# Altium schematic sheet GIG_ENET.SchDoc(GIG_ENET)
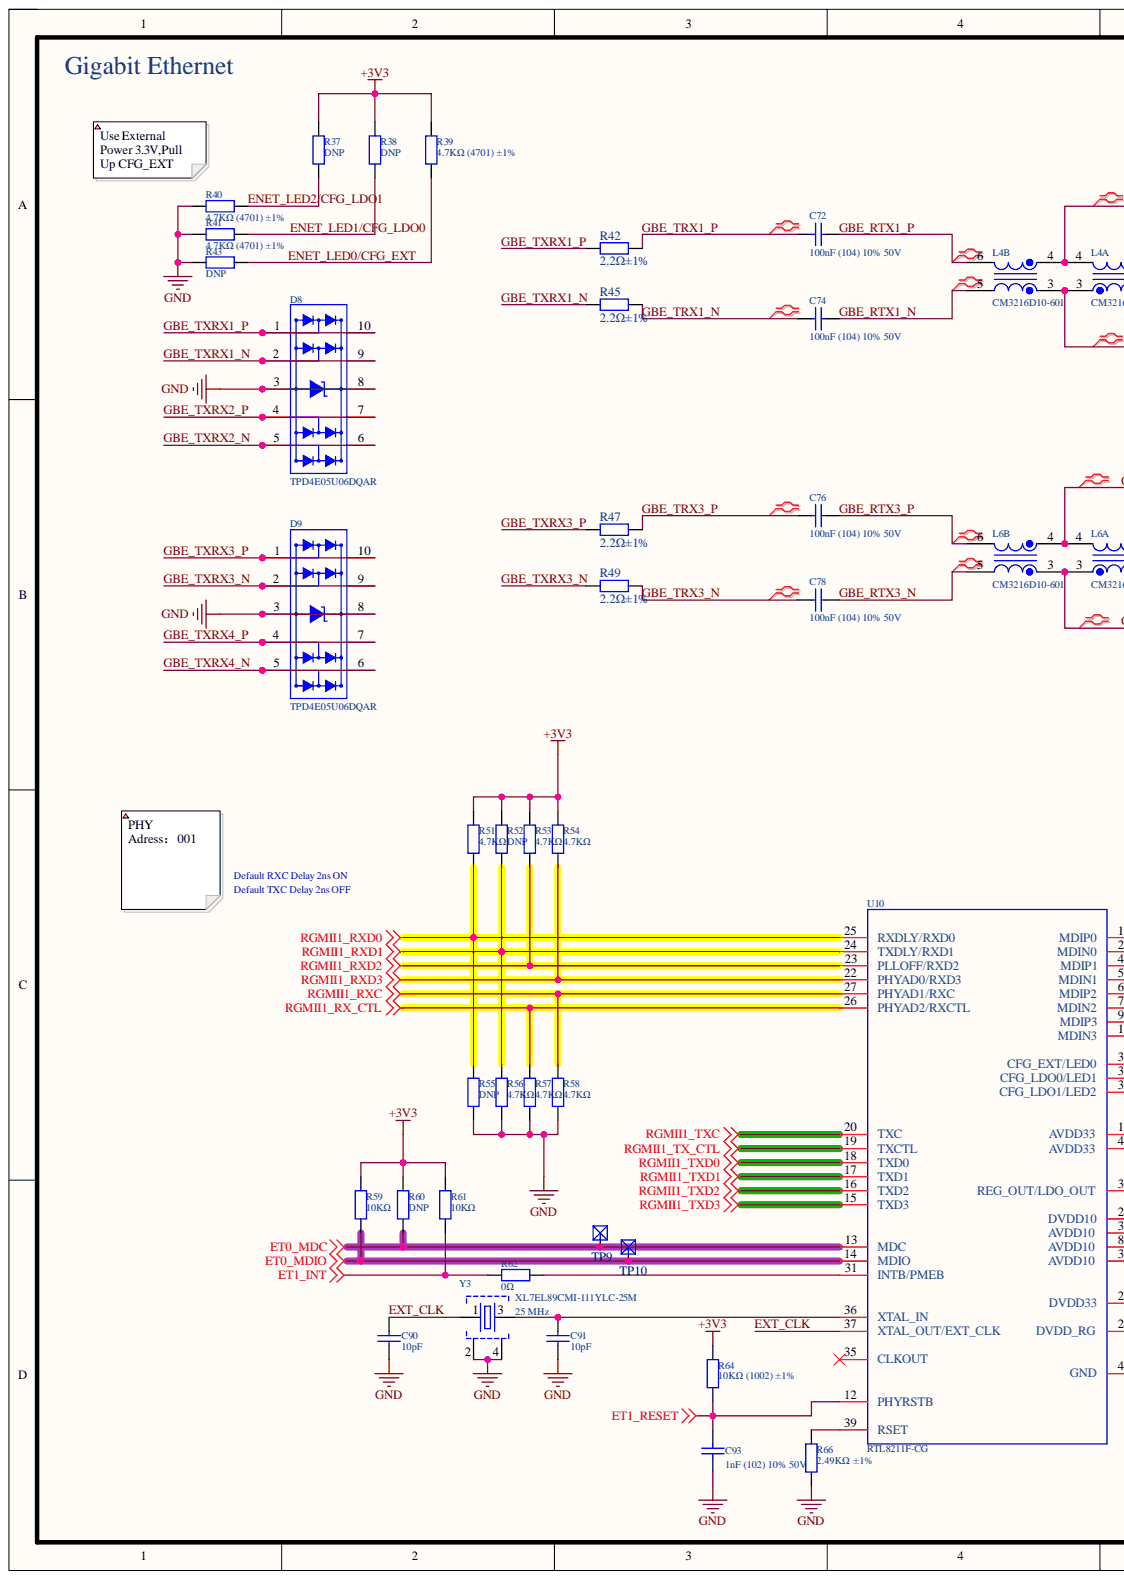
[diagram p0: GIG_ENET.SchDoc, left]
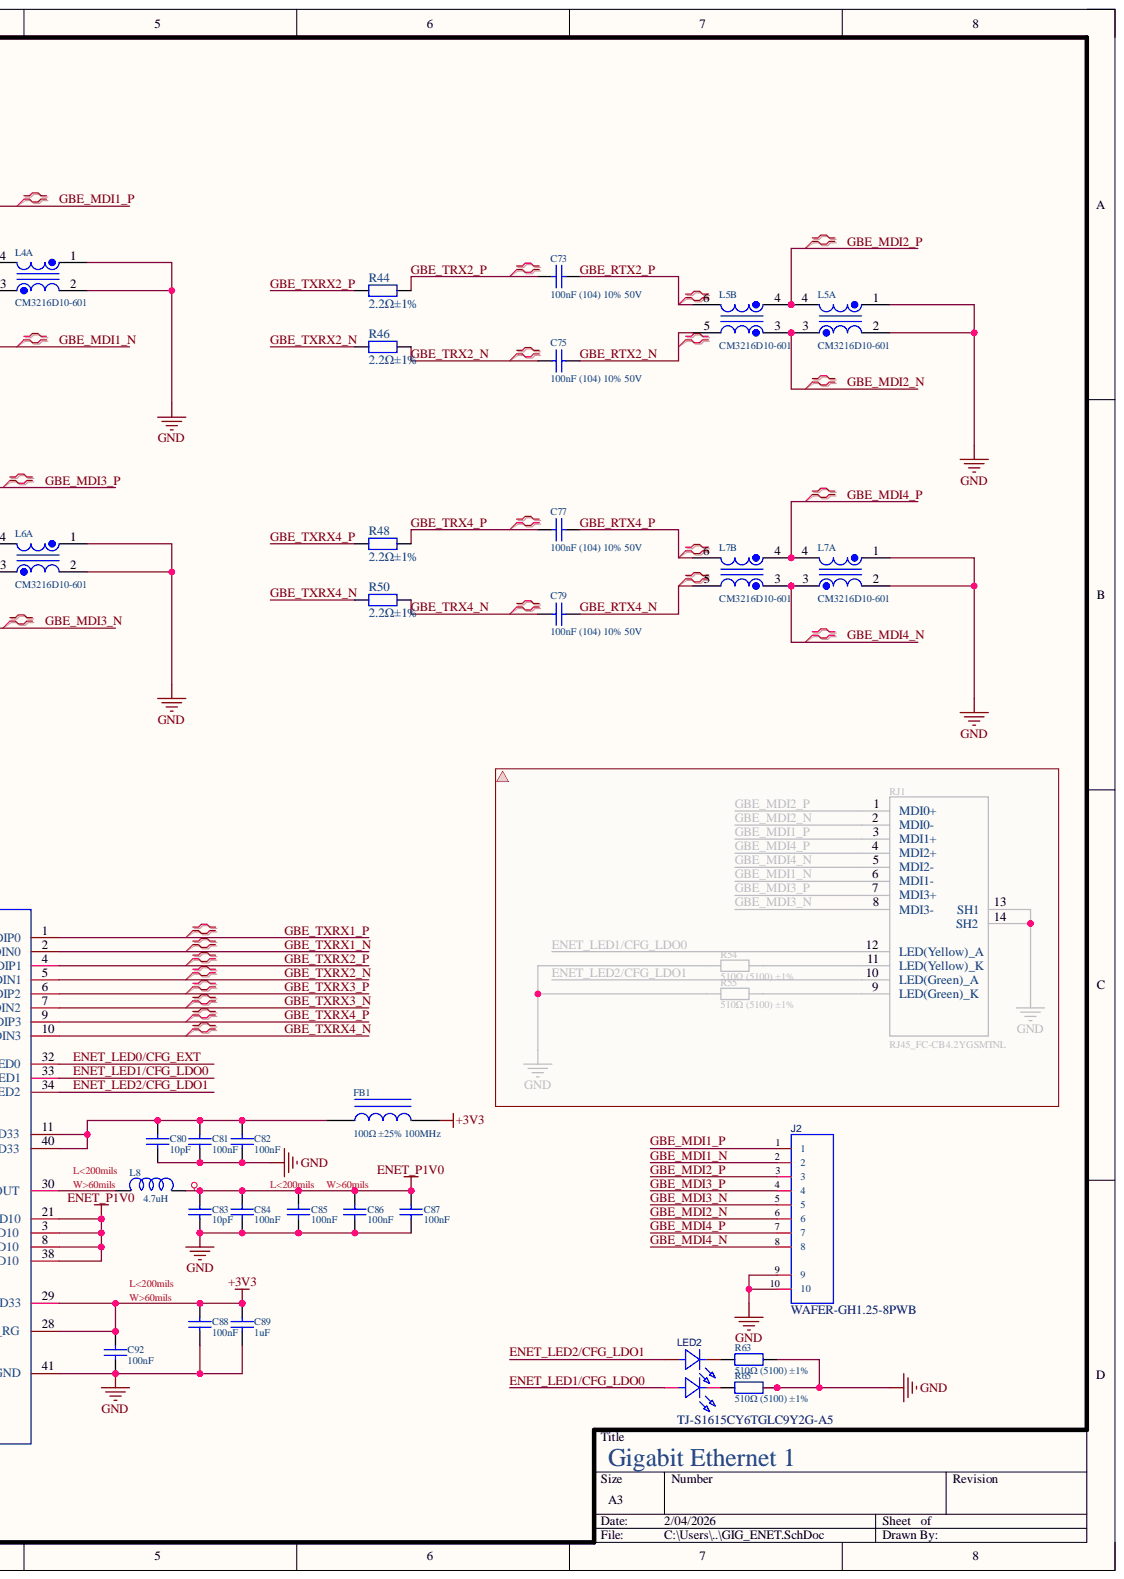
[diagram p1: GIG_ENET.SchDoc, right]

## Parts
C72  100nF (104) 10% 50V  [C0402]
C73  100nF (104) 10% 50V  [C0402]
C74  100nF (104) 10% 50V  [C0402]
C75  100nF (104) 10% 50V  [C0402]
C76  100nF (104) 10% 50V  [C0402]
C77  100nF (104) 10% 50V  [C0402]
C78  100nF (104) 10% 50V  [C0402]
C79  100nF (104) 10% 50V  [C0402]
C80  10pF  [C0402]
C81  100nF  [C0201]
C82  100nF  [C0201]
C83  10pF  [C0402]
C84  100nF  [C0201]
C85  100nF  [C0201]
C86  100nF  [C0201]
C87  100nF  [C0201]
C88  100nF  [C0201]
C89  Capacitance: 1uF  [C0402]  (hidden comment: 1uF 16V)
C90  10pF  [C0402]
C91  10pF  [C0402]
C92  100nF  [C0201]
C93  1nF (102) 10% 50V  [C0402]
D8  TPD4E05U06DQAR  [USON-10_L2.5-W1.0-P0.50-BL]
D9  TPD4E05U06DQAR  [USON-10_L2.5-W1.0-P0.50-BL]
FB1  100Ω ±25% 100MHz  [0805_L]
J2  WAFER-GH1.25-8PWB  [CONN-SMD_8P-P1.25_XUNPU-WAFER-GH1.25-8PWB]
L4  CM3216D10-601  [FILTER-SMD_6P-L3.4-W1.6_SFI1206-601R201]  (parts A,B)
L5  CM3216D10-601  [FILTER-SMD_6P-L3.4-W1.6_SFI1206-601R201]  (parts A,B)
L6  CM3216D10-601  [FILTER-SMD_6P-L3.4-W1.6_SFI1206-601R201]  (parts A,B)
L7  CM3216D10-601  [FILTER-SMD_6P-L3.4-W1.6_SFI1206-601R201]  (parts A,B)
L8  Inductance: 4.7uH  [FB_0805]  (hidden comment: IND-100023-01)
LED2  TJ-S1615CY6TGLC9Y2G-A5  [LED-SMD_4P-L1.6-W1.5_TJ-S1615CY6TGLC9Y2G-A5]
R37  DNP  [R0402]
R38  DNP  [R0402]
R39  4.7KΩ (4701) ±1%  [R0402]
R40  4.7KΩ (4701) ±1%  [R0402]
R41  4.7KΩ (4701) ±1%  [R0402]
R42  2.2Ω±1%  [R0201]
R43  DNP  [R0402]
R44  2.2Ω±1%  [R0201]
R45  2.2Ω±1%  [R0201]
R46  2.2Ω±1%  [R0201]
R47  2.2Ω±1%  [R0201]
R48  2.2Ω±1%  [R0201]
R49  2.2Ω±1%  [R0201]
R50  2.2Ω±1%  [R0201]
R51  4.7KΩ  [R0402]
R52  DNP  [R0402]
R53  4.7KΩ  [R0402]
R54  4.7KΩ  [R0402]
R55  DNP  [R0402]
R56  4.7KΩ  [R0402]
R57  4.7KΩ  [R0402]
R58  4.7KΩ  [R0402]
R59  10KΩ  [R0402]
R60  DNP  [R0402]
R61  10KΩ  [R0402]
R62  0Ω  [R0402]
R63  510Ω (5100) ±1%  [R0402]
R64  10KΩ (1002) ±1%  [R0402]
R65  510Ω (5100) ±1%  [R0402]
R66  2.49KΩ  ±1%  [R0402]
TP9  TEST POINT  [TP_M_0.5]  (value not drawn)
TP10  TEST POINT  [TP_M_0.5]  (value not drawn)
U10  RTL8211F-CG  [WQFN-40_L5.0-W5.0-P0.4-BL-EP]
Y3  XL7EL89CMI-111YLC-25M  [CYR-2016]

## Nets
+3V3: C88.1 C89.1 C92.1 FB1.2 R37.2 R38.2 R39.2 R51.2 R52.2 R53.2 R54.2 R59.2 R60.2 R61.2 R64.2 U10.28(DVDD_RG) U10.29(DVDD33)
ENET_P1V0: C83.2 C84.2 C85.2 C86.2 C87.2 L8.2 U10.3(AVDD10) U10.8(AVDD10) U10.21(DVDD10) U10.38(AVDD10)
ET0_MDC: R60.1 TP9.1 U10.13(MDC)
ET0_MDIO: R59.1 TP10.1 U10.14(MDIO)
ET1_INT: R61.1 R62.1
ET1_RESET: C93.2 R64.1 U10.12(PHYRSTB)
GND: C80.2 C81.1 C82.2 C83.1 C84.1 C85.1 C86.1 C87.1 C88.2 C89.2 C90.1 C91.1 C92.2 C93.1 D8.3 D8.8 D9.3 D9.8 J2.9 J2.10 L4.1 L4.2 L5.1 L5.2 L6.1 L6.2 L7.1 L7.2 R40.1 R41.1 R43.1 R55.1 R56.1 R57.1 R58.1 R63.1 R65.1 R66.1 U10.41(GND) Y3.2 Y3.4
RGMII1_RX_CTL: R57.2 U10.26(PHYAD2/RXCTL)
RGMII1_RXC: R58.2 U10.27(PHYAD1/RXC)
RGMII1_RXD0: R51.1 R55.2 U10.25(RXDLY/RXD0)
RGMII1_RXD1: R52.1 R56.2 U10.24(TXDLY/RXD1)
RGMII1_RXD2: R53.1 U10.23(PLLOFF/RXD2)
RGMII1_RXD3: R54.1 U10.22(PHYAD0/RXD3)
RGMII1_TX_CTL: U10.19(TXCTL)
RGMII1_TXC: U10.20(TXC)
RGMII1_TXD0: U10.18(TXD0)
RGMII1_TXD1: U10.17(TXD1)
RGMII1_TXD2: U10.16(TXD2)
RGMII1_TXD3: U10.15(TXD3)
ENET_LED0/CFG_EXT: R39.1 R43.2 U10.32(CFG_EXT/LED0)
ENET_LED1/CFG_LDO0: LED2.1 R38.1 R41.2 U10.33(CFG_LDO0/LED1)
ENET_LED2/CFG_LDO1: LED2.3 R37.1 R40.2 U10.34(CFG_LDO1/LED2)
EXT_CLK: C90.2 U10.37(XTAL_OUT/EXT_CLK) Y3.1
GBE_MDI1_N: J2.2 L4.3
GBE_MDI1_P: J2.1 L4.4
GBE_MDI2_N: J2.6 L5.3
GBE_MDI2_P: J2.3 L5.4
GBE_MDI3_N: J2.5 L6.3
GBE_MDI3_P: J2.4 L6.4
GBE_MDI4_N: J2.8 L7.3
GBE_MDI4_P: J2.7 L7.4
GBE_RTX1_N: C74.2 L4.5
GBE_RTX1_P: C72.2 L4.6
GBE_RTX2_N: C75.2 L5.5
GBE_RTX2_P: C73.2 L5.6
GBE_RTX3_N: C78.2 L6.5
GBE_RTX3_P: C76.2 L6.6
GBE_RTX4_N: C79.2 L7.5
GBE_RTX4_P: C77.2 L7.6
GBE_TRX1_N: C74.1 R45.2
GBE_TRX1_P: C72.1 R42.2
GBE_TRX2_N: C75.1 R46.2
GBE_TRX2_P: C73.1 R44.2
GBE_TRX3_N: C78.1 R49.2
GBE_TRX3_P: C76.1 R47.2
GBE_TRX4_N: C79.1 R50.2
GBE_TRX4_P: C77.1 R48.2
GBE_TXRX1_N: D8.2 D8.9 R45.1 U10.2(MDIN0)
GBE_TXRX1_P: D8.1 D8.10 R42.1 U10.1(MDIP0)
GBE_TXRX2_N: D8.5 D8.6 R46.1 U10.5(MDIN1)
GBE_TXRX2_P: D8.4 D8.7 R44.1 U10.4(MDIP1)
GBE_TXRX3_N: D9.2 D9.9 R49.1 U10.7(MDIN2)
GBE_TXRX3_P: D9.1 D9.10 R47.1 U10.6(MDIP2)
GBE_TXRX4_N: D9.5 D9.6 R50.1 U10.10(MDIN3)
GBE_TXRX4_P: D9.4 D9.7 R48.1 U10.9(MDIP3)
NetC80_1: C80.1 C81.2 C82.1 FB1.1 U10.11(AVDD33) U10.40(AVDD33)
NetC91_2: C91.2 U10.36(XTAL_IN) Y3.3
NetL8_1: L8.1 U10.30(REG_OUT/LDO_OUT)
NetLED2_2: LED2.2 R65.2
NetLED2_4: LED2.4 R63.2
NetR62_2: R62.2 U10.31(INTB/PMEB)
NetR66_2: R66.2 U10.39(RSET)

Not connected: U10 35(CLKOUT)

## Library data of the parts
C72-C79 = 0402 100nF (104) 10% 50V: GRM155R71H104KE14D,100nF (104) 10% 50V; Component Kind: Standard; ComponentLink1Description: 供应商链接; ComponentLink1URL: https://item.szlcsc.com/78147.html?fromZone=l_c__%2522catalog%2522&spm=sc.cid.xh1.zy.n___sc.gb.xh1.zy.l&lcsc_vid=FgdZAQZURgVfVlRQQQNbBAcFQVZYX1NeQ1BYX10ARFkxVlNSQ1FZUVReQVdaUjsOAxUeFF5JWAgaAglIBBsCBBcFWQIBCks%3D; Library Name: RA8P1.SCHLIB; Package: 0603; Supplier: LC; SuppliersPartNumber: C1525
C80 C83 = 0402 4.7uF (475) 20% 10V: 贴片电容; Component Kind: Standard; ComponentLink1Description: 供应商链接; ComponentLink1URL: http://www.szlcsc.com/product/details_24469.html; Library Name: RA8P1.SCHLIB; Package: 0402; Supplier: LC; SuppliersPartNumber: C23733
C81 C82 C84-C88 C92 = CAP-000030-01: 0201,100nF ±10% 16V，X7R; Legacy Part Number: 151-10004022; Manufacturer: muRata; Manufacturer Part Number 1: GRM033Z71C104KE14D; Dialectric: X7R; Notes: ANY EQUIVALENT; Voltage: 16V; Capacitance: 100nF; ClassName: MCU; Component Kind: Standard; ComponentLink1Description: New link; ComponentLink1URL: https://item.szlcsc.com/698285.html?fromZone=l_c__%2522catalog%2522&spm=sc.cid.xh3.zy.n___sc.gb.xh1.zy.l&lcsc_vid=FgdZAQZURgVfVlRQQQNbBAcFQVZYX1NeQ1BYX10ARFkxVlNSQ1FZUVReQVdaUjsOAxUeFF5JWAgaAglIBBsCBBcFWQIBCks%3D; Library Name: RA8P1.SCHLIB; Manufacturer 1: muRata; Manufacturer 3: AVX; Manufacturer Part Number 3: 0402YC104KAT2A; Mfg: muRata; Mfg P/N: GRM033Z71C104KE14D; Mfg Part Number: GRM033Z71C104KE14D; Package: 0402; PN: GRM033Z71C104KE14D; Supplier 1: Arrow Electronics; Supplier 2: Digi-Key; Supplier 3: Newark; Supplier 4: Mouser; Supplier Part Number 1: CC0402KRX7R8BB104; Supplier Part Number 2: 311-2077-1-ND; Supplier Part Number 3: 40AJ6370; Supplier Part Number 4: 603-CC402KRX7R8BB104; Tolerance: 10%
C89 = CAP-000063-01: Ceramic Chip Capacitor 0402 1uF 16V; Manufacturer: Murata; Manufacturer Part Number 1: GRM155R61E105MA12D; Dialectric: X5R; Notes: ANY EQUIVALENT; Tolerance: 10%; Value: 1u; Component Kind: Standard; Library Name: RA8P1.SCHLIB; Manufacturer 1: Murata; Manufacturer 2: Samsung; Manufacturer Part Number 2: CL05A105KO5NNNC; Mfg: Murata; Mfg P/N: GRM155R61E105MA12D; Mfg Part Number: GRM155R61E105MA12D; Package: 0402; PN: GRM155R61E105MA12D; Simulation: C0402_X5R_1UF; Supplier 1: Digi-Key; Supplier 2: Digi-Key; Supplier 3: Digi-Key; Supplier Part Number 1: 1276-1067-1-ND; Supplier Part Number 2: 1276-1067-6-ND; Supplier Part Number 3: 490-10018-1-ND; Voltage: 16V
C90 C91 = CAP-100118-01: Ceramic Chip Capacitor 0402 10pF 50V; Legacy Part Number: 151-10005153; Manufacturer: Yageo; Manufacturer Part Number 1: CC0402JRNPO9BN100; Dialectric: COG/NPO; Notes: ANY EQUIVALENT; Tolerance: 5%; Capacitance: 10pF; ClassName: JLOB; Component Kind: Standard; Library Name: RA8P1.SCHLIB; Manufacturer 1: Yageo; Mfg: Yageo; Mfg P/N: CC0402JRNPO9BN100; Mfg Part Number: CC0402JRNPO9BN100; Package: 0402; PN: CC0402JRNPO9BN100; Supplier 1: Digi-Key; Supplier Part Number 1: 311-1014-1-ND; Voltage: 50V
C93 = 0402 1nF (102) 10% 50V: 贴片电容; Component Kind: Standard; ComponentLink1Description: 供应商链接; ComponentLink1URL: http://www.szlcsc.com/product/details_1875.html; Library Name: RA8P1.SCHLIB; Package: 0402; Supplier: LC; SuppliersPartNumber: C1523
D8 D9 = TPD4E05U06DQAR: Manufacture: SOCAY(硕凯); Part Name: ESD; Part Number: C040504013981; DSC1: 双向; DSC2: Vdc=5V; DSC3: Vbr=8V; DSC4: Vc=20V; DSC5: Cj=0.4pF; ERP Number: 1010060056; ID: 8; PCB Footprint: DFN2510-10L; Solder: 推荐; Component Kind: Standard; Date: 05132005; Library Name: RA8P1.SCHLIB; Price: 0.15
FB1 = 0805 100Ω 25% 100MHz: 贴片磁珠; Component Kind: Standard; ComponentLink1Description: 供应商链接; ComponentLink1URL: http://www.szlcsc.com/product/details_1367.html; Library Name: RA8P1.SCHLIB; Package: 0805; Supplier: LC; SuppliersPartNumber: C1015
L4-L7 = CM3216D10-601: Cap+CMC Solution, Inductance=55uH(100Khz), 0.2A,125V; Component Kind: Standard; Library Name: RA8P1.SCHLIB
L8 = IND-100023-01: Chip Inductor, 4.7uH Shielded Multilayer, 700mA 260mohm 0805 20%; Manufacturer: TDK; DisplayName: MLP2012S4R7MT0S1; Component Kind: Standard; Current: 700mA; DC Resistance: 260mohm; Library Name: RA8P1.SCHLIB; Manufacturer 1: TDK; Manufacturer Part Number 1: TDK; Mfg: TDK; Mfg Part Number: TDK; Package: 0805; PN: MLP2012S4R7MT0S1; Supplier 1: Arrow Electronics; Supplier 2: Digi-Key; Supplier 3: Mouser; Supplier 4: Digi-Key; Supplier 5: Mouser; Supplier Part Number 1: MLP2012S4R7MT0S1; Supplier Part Number 2: 445-6366-1-ND; Supplier Part Number 3: 810-MLP2012S4R7MT0S1; Supplier Part Number 4: 553-BKPB002012104R7MA2CT-ND; Supplier Part Number 5: 603-BKPB2012104R7MA2; Tolerance: 20%
LED2 = TJ-S1615CY6TGLC9Y2G-A5: 黄，绿双色,1对应黄灯A; Component Kind: Standard; Library Name: RA8P1.SCHLIB; Symbol: TJ-S1615CY6TGLC9Y2G-A5
R37-R41 R43 R51-R58 = 0402 4.7KΩ (4701) 1%: 贴片电阻; Component Kind: Standard; ComponentLink1Description: 供应商链接; ComponentLink1URL: http://www.szlcsc.com/product/details_26643.html; Library Name: RA8P1.SCHLIB; Package: 0402; Supplier: LC; SuppliersPartNumber: C25900
R42 R44-R50 = 0201 2.2Ω 1%: 贴片电阻; Component Kind: Standard; ComponentLink1Description: 供应商链接; ComponentLink1URL: https://item.szlcsc.com/25844.html?fromZone=s_s__%25222.2r%2522&spm=sc.gb.xh1.zy.n___sc.gb.hd.ss&lcsc_vid=E1YIU1FRQVIMBVNRRVhcBAYDQwJbUQICQFgLBVMDElIxVlNSQlJZX1dWRVBcUjsOAxUeFF5JWBYZEEoVDQ0NFAdIFA4DSA%3D%3D; Library Name: RA8P1.SCHLIB; Package: 0402; Supplier: LC; SuppliersPartNumber: C25879
R59-R61 R64 = 0402 10KΩ (1002) 1%: 贴片电阻，10K; Component Kind: Standard; ComponentLink1Description: 供应商链接; ComponentLink1URL: https://item.szlcsc.com/26487.html?fromZone=l_b__%2522brand%2522&spm=sc.bid.xh1.zy.n&lcsc_vid=FANcVFBQFQMNX1cFFAdZVVZXEgdeAgdWFlQNUVAHT1ExVlNSRFhXVlJQQFlYVzsOAxUeFF5JWA0HFBBIBBsCBBcFWQIBCksEBQAAA0tfTk8GEwkK; Library Name: RA8P1.SCHLIB; Package: 0402; Supplier: LC; SuppliersPartNumber: C25744
R62 = 0402 0Ω (0R0) 1%: 贴片电阻; Component Kind: Standard; ComponentLink1Description: 供应商链接; ComponentLink1URL: http://www.szlcsc.com/product/details_17853.html; Library Name: RA8P1.SCHLIB; Package: 0402; Supplier: LC; SuppliersPartNumber: C17168
R63 R65 = 0402 510Ω (5100) 1%: 贴片电阻; Component Kind: Standard; ComponentLink1Description: 供应商链接; ComponentLink1URL: http://www.szlcsc.com/product/details_25866.html; Library Name: RA8P1.SCHLIB; Package: 0402; Supplier: LC; SuppliersPartNumber: C25123
R66 = 0402 2.49KΩ 1%: 贴片电阻; Component Kind: Standard; ComponentLink1Description: 供应商链接; ComponentLink1URL: http://www.szlcsc.com/product/details_26625.html; Library Name: RA8P1.SCHLIB; Package: 0402; Supplier: LC; SuppliersPartNumber: C25882
TP9 TP10 = TP: Component Kind: Standard; Library Name: RA8P1.SCHLIB
U10 = RTL8211F-CG: RGMII; Manufacturer: REALTEK(瑞昱); Add into BOM: yes; Number of Channels: 4; Supply Voltage: 1.8V；2.5V；3.3V；1.5V; Application Function: -; Component Kind: Standard; Convert to PCB: yes; Datasheet: https://atta.szlcsc.com/upload/public/pdf/source/20190902/C187932_1913F3711F05FE1324DF2946D70D648D.pdf; Device: RTL8211F-CG; Interface: RGMII; JLCPCB Part Class: Extended Part; LCSC Part Name: 集成10/100/1000M以太网精密收发器; Library Name: RA8P1.SCHLIB; Manufacturer Part: RTL8211F-CG; PCB3D: WQFN-40_L5.0-W5.0-P0.4-BL-EP; Supplier: LCSC; Supplier Footprint: WQFN-40-EP(5x5); Supplier Part: C187932; Symbol: RTL8211F-CG
Y3 = XTL-100008-01: 25MHz ±10ppm 10pF，100ohom ESR  XL7EL89CMI-111YLC-25M; Manufacturer: ECS; Manufacturer Part Number 1: ECS-250-10-37B-CTN-TR; ESR: 100 ohm; Freq Stability: +/- 20ppm; Freq Tolerance: +/- 10ppm; Load Capacitance: 10pF; Notes: MUST USE SPECIFIC; Component Kind: Standard; ComponentLink1Description: New link; ComponentLink1URL: https://item.szlcsc.com/23919117.html?fromZone=l_b__%2522brand%2522&spm=sc.bidn.xh6.zy.n___sc.gbn.xh1.zy.p&lcsc_vid=FlRcAQUARlUMU1NWEQALBQBeQllfVQcHQVAMX1FeFAcxVlNSTlBXXlFTQFBfUDsOAxUeFF5JWAgaAglIBBsCBBcFWQIBCks%3D; Frequency: 25 MHz; Library Name: RA8P1.SCHLIB; Manufacturer 1: ECS; Mfg: ECS; Mfg Part Number: ECS-250-10-37B-CTN-TR; PN: ECS-250-10-37B-CTN-TR; Supplier 1: Avnet; Supplier 2: Mouser; Supplier 3: Digi-Key; Supplier Part Number 1: ECS-250-10-37B-CTN-TR; Supplier Part Number 2: 520-250-10-37B-CTNT; Supplier Part Number 3: XC3065CT-ND
J2 = WAFER-GH1.25-8PWB: Component Kind: Standard; Library Name: RA8P1.SCHLIB; Symbol: WAFER-GH1.25-8PWB
